# Altium schematic sheet [04] CM4_PART1.SchDoc(BLK1)
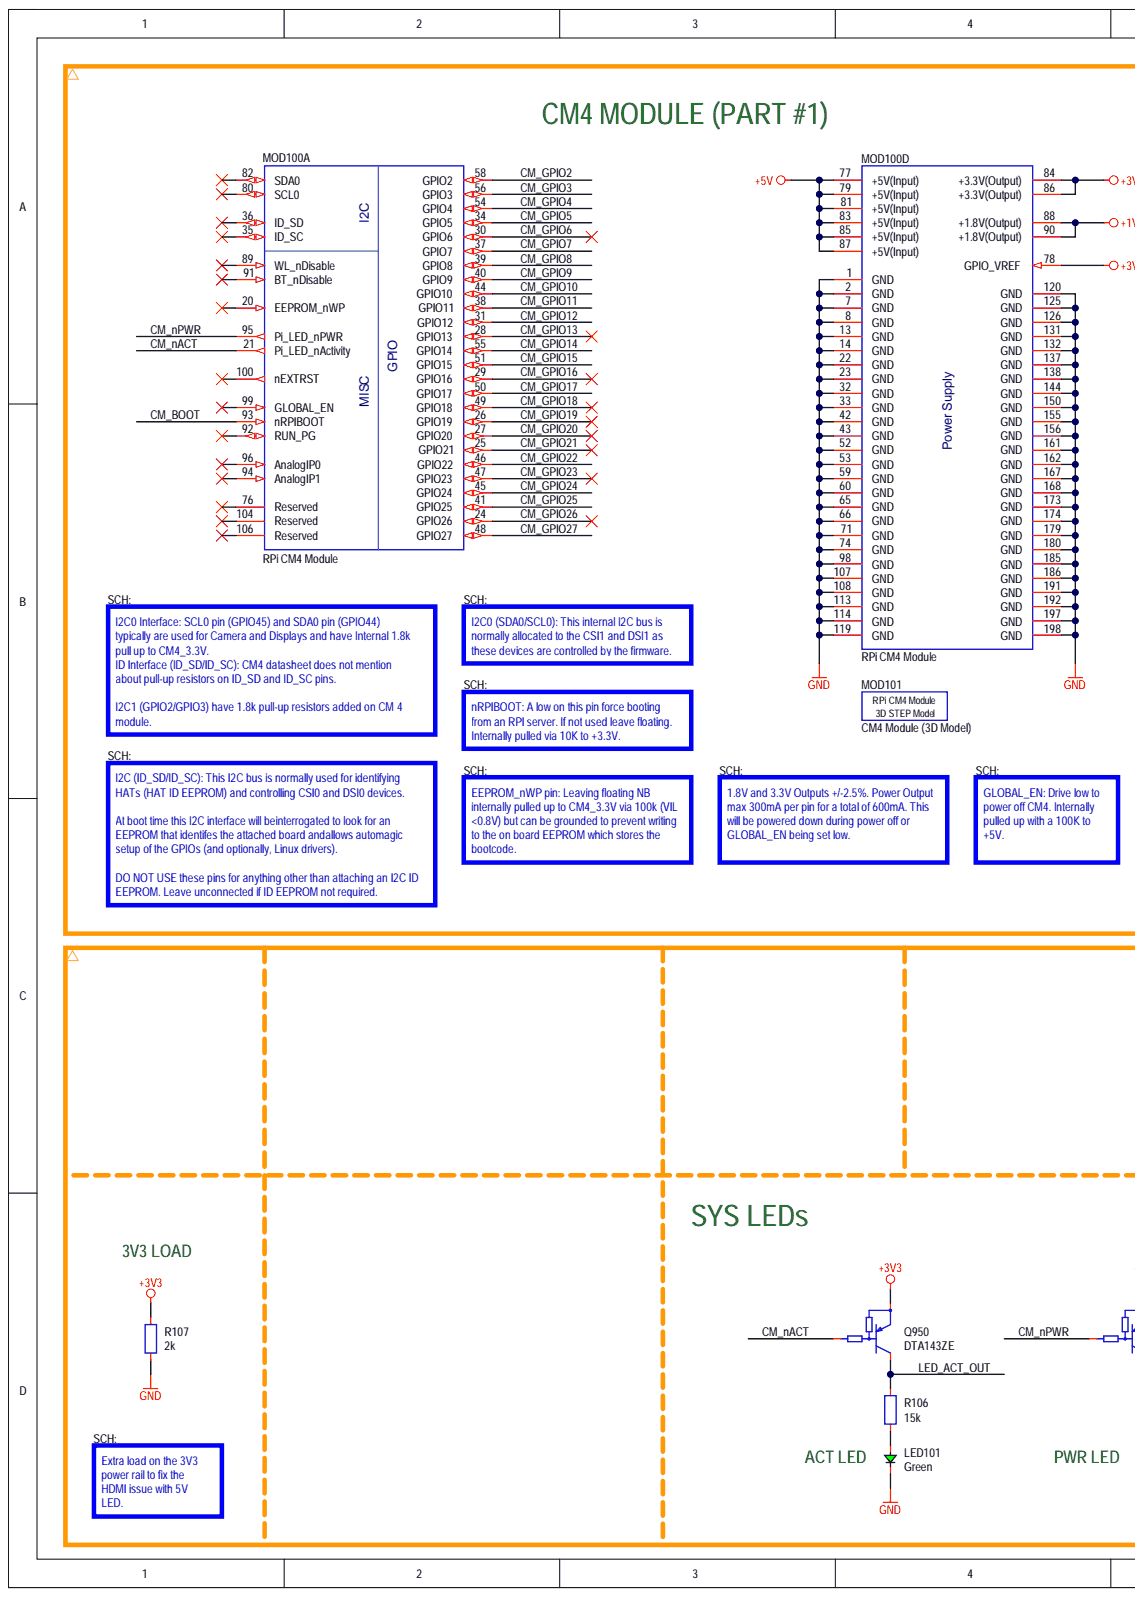
[diagram p0: [04] CM4_PART1.SchDoc, left]
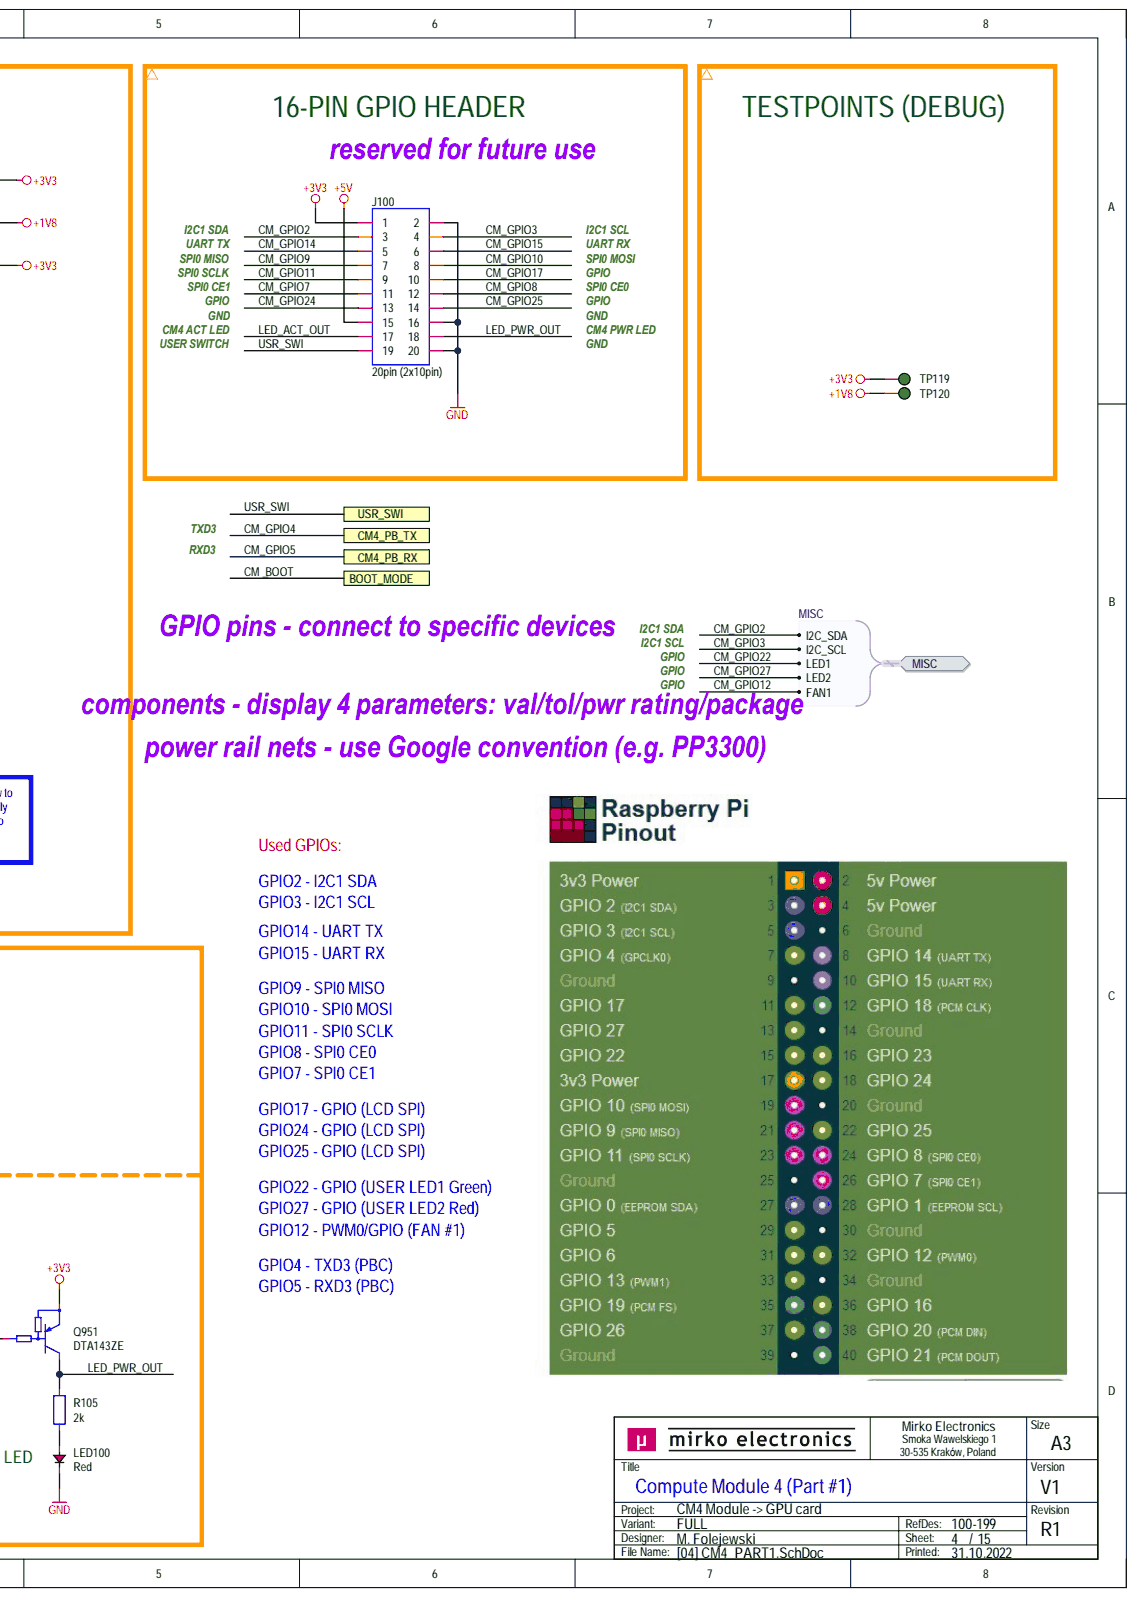
[diagram p1: [04] CM4_PART1.SchDoc, right]

## Parts
J100  20pin (2x10pin)  [CON_HDR_P254_2X10_STR]
LED100  Red  Component Type: LED  [LED_0805_RED_R]
LED101  Green  Component Type: LED  [LED_0805_GRN_R]
MOD100  RPi CM4 Module  MPN: CM4 Module  [MOD_RPI_CM4_SOCKET_P40_C300]  (parts A,D)
MOD101  CM4 Module (3D Model)  Component Subtype: 3D STEP Model  [MOD_RPI_CM4_3D_C300_A1]  (no BOM)
Q950  DTA143ZE  [PKG_SOT523_SC75_SOT416_P100_R]
Q951  DTA143ZE  [PKG_SOT523_SC75_SOT416_P100_R]
R105  2k  [RES_0603_R]
R106  15k  [RES_0603_R]
R107  2k  [RES_0603_R]
TP119  ICT  [PCB_TP_ICT_100]  (value not drawn)  (no BOM)
TP120  ICT  [PCB_TP_ICT_100]  (value not drawn)  (no BOM)

## Ports
BOOT_MODE, CM4_PB_RX, CM4_PB_TX, MISC, MISC.FAN1, MISC.I2C_SCL, MISC.I2C_SDA, MISC.LED1, MISC.LED2, USR_SWI

## Nets
+1V8: MOD100.88(+1.8V(Output)) MOD100.90(+1.8V(Output)) TP120.1
+3V3: J100.1 MOD100.78(GPIO_VREF) MOD100.84(+3.3V(Output)) MOD100.86(+3.3V(Output)) Q950.2 Q951.2 R107.2 TP119.1
+5V: J100.15 MOD100.77(+5V(Input)) MOD100.79(+5V(Input)) MOD100.81(+5V(Input)) MOD100.83(+5V(Input)) MOD100.85(+5V(Input)) MOD100.87(+5V(Input))
GND: J100.2 J100.16 J100.20 LED100.1 LED101.1 MOD100.1(GND) MOD100.2(GND) MOD100.7(GND) MOD100.8(GND) MOD100.13(GND) MOD100.14(GND) MOD100.22(GND) MOD100.23(GND) MOD100.32(GND) MOD100.33(GND) MOD100.42(GND) MOD100.43(GND) MOD100.52(GND) MOD100.53(GND) MOD100.59(GND) MOD100.60(GND) MOD100.65(GND) MOD100.66(GND) MOD100.71(GND) MOD100.74(GND) MOD100.98(GND) MOD100.107(GND) MOD100.108(GND) MOD100.113(GND) MOD100.114(GND) MOD100.119(GND) MOD100.120(GND) MOD100.125(GND) MOD100.126(GND) MOD100.131(GND) MOD100.132(GND) MOD100.137(GND) MOD100.138(GND) MOD100.144(GND) MOD100.150(GND) MOD100.155(GND) MOD100.156(GND) MOD100.161(GND) MOD100.162(GND) MOD100.167(GND) MOD100.168(GND) MOD100.173(GND) MOD100.174(GND) MOD100.179(GND) MOD100.180(GND) MOD100.185(GND) MOD100.186(GND) MOD100.191(GND) MOD100.192(GND) MOD100.197(GND) MOD100.198(GND) R107.1
CM_BOOT: MOD100.93(nRPIBOOT) ; port BOOT_MODE
CM_GPIO2: J100.3 MOD100.58(GPIO2) ; port MISC
CM_GPIO3: J100.4 MOD100.56(GPIO3) ; port MISC
CM_GPIO4: MOD100.54(GPIO4) ; port CM4_PB_TX
CM_GPIO5: MOD100.34(GPIO5) ; port CM4_PB_RX
CM_GPIO6: MOD100.30(GPIO6)
CM_GPIO7: J100.11 MOD100.37(GPIO7)
CM_GPIO8: J100.12 MOD100.39(GPIO8)
CM_GPIO9: J100.7 MOD100.40(GPIO9)
CM_GPIO10: J100.8 MOD100.44(GPIO10)
CM_GPIO11: J100.9 MOD100.38(GPIO11)
CM_GPIO12: MOD100.31(GPIO12) ; port MISC
CM_GPIO13: MOD100.28(GPIO13)
CM_GPIO14: J100.5 MOD100.55(GPIO14)
CM_GPIO15: J100.6 MOD100.51(GPIO15)
CM_GPIO16: MOD100.29(GPIO16)
CM_GPIO17: J100.10 MOD100.50(GPIO17)
CM_GPIO18: MOD100.49(GPIO18)
CM_GPIO19: MOD100.26(GPIO19)
CM_GPIO20: MOD100.27(GPIO20)
CM_GPIO21: MOD100.25(GPIO21)
CM_GPIO22: MOD100.46(GPIO22) ; port MISC
CM_GPIO23: MOD100.47(GPIO23)
CM_GPIO24: J100.13 MOD100.45(GPIO24)
CM_GPIO25: J100.14 MOD100.41(GPIO25)
CM_GPIO26: MOD100.24(GPIO26)
CM_GPIO27: MOD100.48(GPIO27) ; port MISC
CM_nACT: MOD100.21(Pi_LED_nActivity) Q950.1
CM_nPWR: MOD100.95(Pi_LED_nPWR) Q951.1
LED_ACT_OUT: J100.17 Q950.3 R106.2
LED_PWR_OUT: J100.18 Q951.3 R105.2
USR_SWI: J100.19 ; port USR_SWI
NetLED100_2: LED100.2 R105.1
NetLED101_2: LED101.2 R106.1

Not connected: MOD100 20(EEPROM_nWP) 35(ID_SC) 36(ID_SD) 76(Reserved) 80(SCL0) 82(SDA0) 89(WL_nDisable) 91(BT_nDisable) 92(RUN_PG) 94(AnalogIP1) 96(AnalogIP0) 99(GLOBAL_EN) 100(nEXTRST) 104(Reserved) 106(Reserved)

## Library data of the parts
J100 = CON_2X10_ROW: Connector; 2 Row;; Manufacturer: GENERIC; Component Subtype: Pin Header; Package (Case): THT; Component Kind: Standard; Component Type: Connector; Mounting Type: THT; MPN: GENERIC; Supplier: ?; Value: 20pin (2x10pin); Library Name: MirFol_lib.SchLib
LED100 = DIO_LED_RED: Diode; LED; Red;; Manufacturer: Foshan NationStar Optoelectronics; MPN: NCD0805R1; Component Subtype: LED Diode; Package (Case): 0805 (2012); Component Kind: Standard; Mounting Type: SMD; Supplier: LCSC; Value: Red; Library Name: MirFol_lib.SchLib
LED101 = DIO_LED_GRN: Diode; LED; Green;; Manufacturer: Foshan NationStar Optoelectronics; MPN: NCD0805G1; Component Subtype: LED Diode; Package (Case): 0805 (2012); Component Kind: Standard; Mounting Type: SMD; Supplier: LCSC; Value: Green; Library Name: MirFol_lib.SchLib
MOD100 = MOD_RPI_CM4_VAR2: PCB Module; RPi Compute Module 4;; Manufacturer: Raspberry Pi Foundation; Component Subtype: Raspberry Pi CM4; Component Kind: Standard; Component Type: PCB Module; Mounting Type: -; Supplier: ?; Value: RPi CM4 Module; Library Name: MirFol_lib.SchLib
MOD101 = MOD_CM4_3D_MODEL: PCB Module; RPi Compute Module 4; 3D model; Component Type: PCB Module; Manufacturer: -; Mounting Type: -; MPN: -; Package (Case): -; Supplier: -; Value: CM4 Module (3D Model); Library Name: Pineberry_Lib.SchLib
Q950 Q951 = TRA_BJT_PNP_DIG_ONS_DTA143ZE: Transistor; BJT; PNP; Digital (Biased);; Manufacturer: On Semiconductor; MPN: DTA143ZET1G; Component Kind: Standard; Component Type: Transistor; Library Name: AN_lib.SchLib; Mounting Type: SMD; Value: DTA143ZE
R105 R107 = RES-0033: Resistor; Thick Film; 2k2; 1%; 100mW; 100PPM; 75V; Standard; SMD; 0603 (1608);; Manufacturer: Yageo Corporation; MPN: TBD; Database Table Name: RESISTOR$; Component Kind: Standard; Component Subtype: Thick Film; Component Type: Resistor; Mounting Type: SMD; Package (Case): 0603 (1608); Supplier: ?; Value: 2k; Library Name: MirFol_db_local.DbLib
R106 = RES-0043: Resistor; Thick Film; 15k; 1%; 100mW; 100PPM; 75V; Standard; SMD; 0603 (1608);; Manufacturer: Yageo Corporation; MPN: TBD; Database Table Name: RESISTOR$; Component Kind: Standard; Component Subtype: Thick Film; Component Type: Resistor; Mounting Type: SMD; Package (Case): 0603 (1608); Supplier: ?; Value: 15k; Library Name: MirFol_db_local.DbLib
TP119 TP120 = PCB_TP_ICT: Test Point (ICT); Specification: Testpoint (for ICT), SMD pad; Component Type: Testpoint (for ICT); Manufacturer: -; Mounting Type: Non component; MPN: -; Value: ICT; Library Name: MirFol_lib.SchLib
